# Altium schematic sheet Mcu.SchDoc
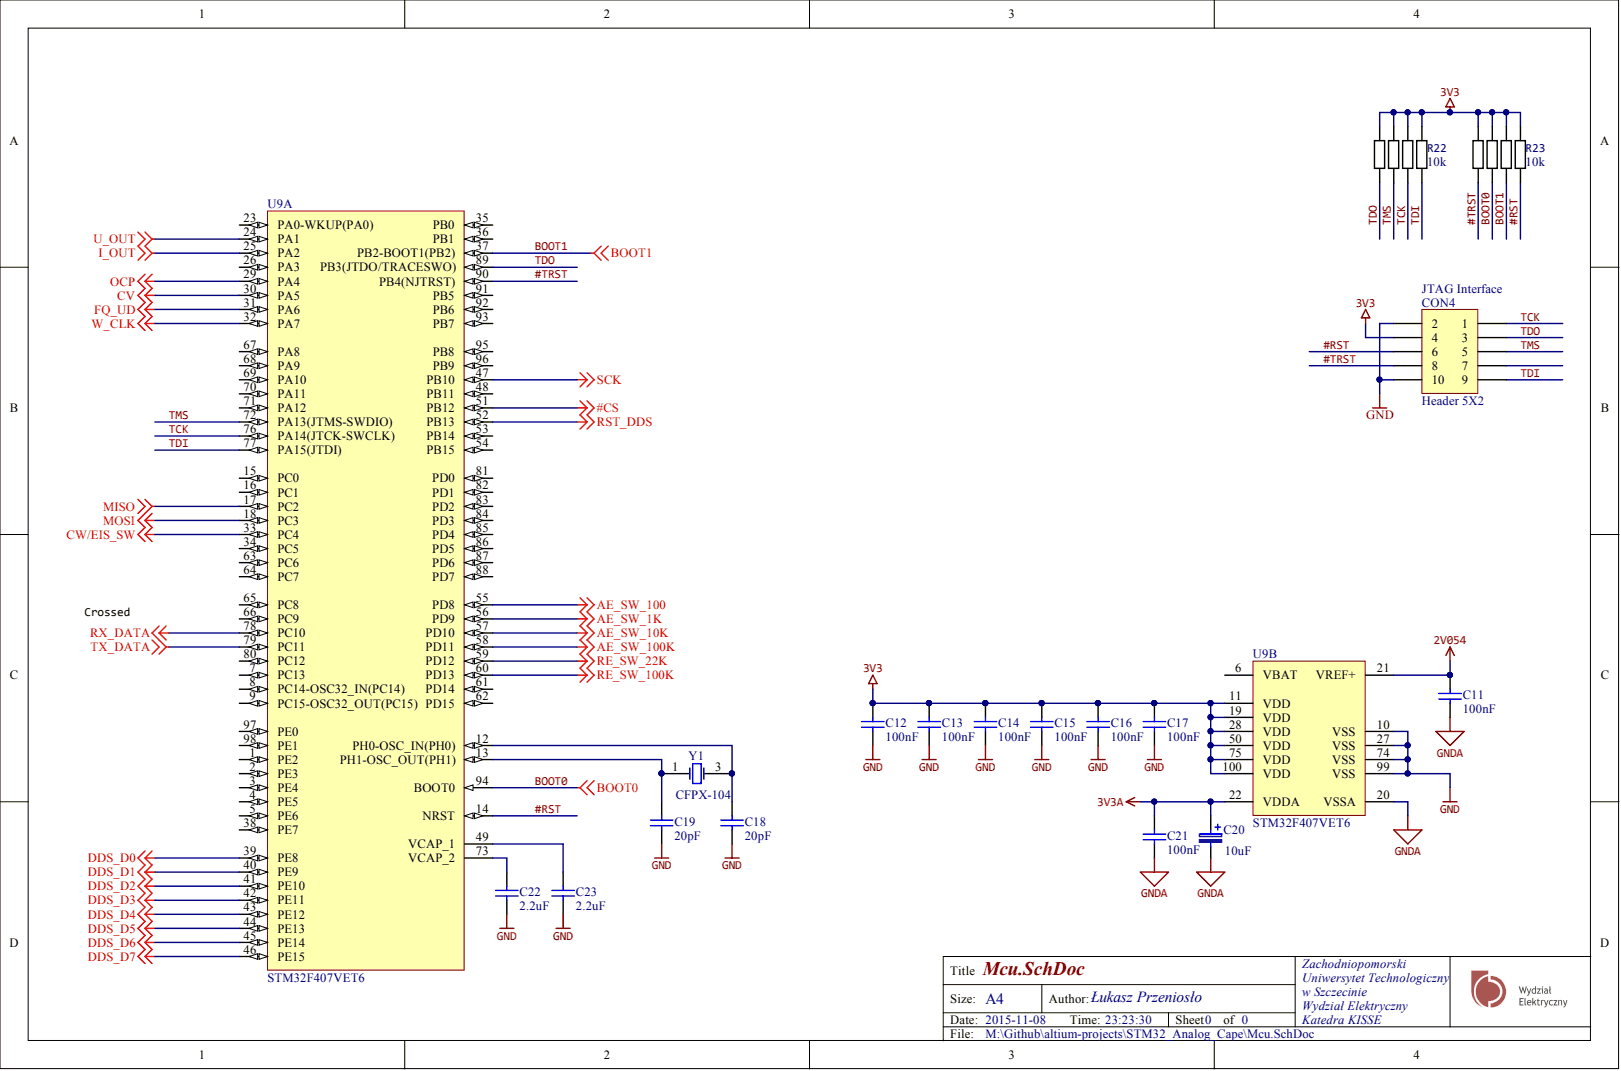
[diagram p0: Mcu.SchDoc]

## Parts
C11  100nF  [CAPC1608N]
C12  100nF  [CAPC1608N]
C13  100nF  [CAPC1608N]
C14  100nF  [CAPC1608N]
C15  100nF  [CAPC1608N]
C16  100nF  [CAPC1608N]
C17  100nF  [CAPC1608N]
C18  20pF  [CAPC1608N]
C19  20pF  [CAPC1608N]
C20  10uF  [SMDA]
C21  100nF  [CAPC1608N]
C22  2.2uF  [CAPC1608N]
C23  2.2uF  [CAPC1608N]
CON4  Header 5X2  [HDR1X10_1.27mmp_MIN]
R22  value 10k  [RES_ARRAY_4x0603]  (hidden comment: RES_ARRAY_4)
R23  value 10k  [RES_ARRAY_4x0603]  (hidden comment: RES_ARRAY_4)
U9  STM32F407VET6  [LQFP100_L]  (parts A,B)
Y1  CFPX-104  [CFPX-104]

## Nets
2V054: C11.2 U9.21(VREF+)
3V3: C12.2 C13.2 C14.2 C15.2 C16.2 C17.2 CON4.4 R22.2 R22.4 R22.6 R22.8 R23.2 R23.4 R23.6 R23.8 U9.11(VDD) U9.19(VDD) U9.28(VDD) U9.50(VDD) U9.75(VDD) U9.100(VDD)
3V3A: C20.1 C21.2 U9.22(VDDA)
#CS: U9.51(PB12)
AE_SW_1K: U9.56(PD9)
AE_SW_10K: U9.57(PD10)
AE_SW_100: U9.55(PD8)
AE_SW_100K: U9.58(PD11)
CV: U9.30(PA5)
CW/EIS_SW: U9.33(PC4)
DDS_D0: U9.39(PE8)
DDS_D1: U9.40(PE9)
DDS_D2: U9.41(PE10)
DDS_D3: U9.42(PE11)
DDS_D4: U9.43(PE12)
DDS_D5: U9.44(PE13)
DDS_D6: U9.45(PE14)
DDS_D7: U9.46(PE15)
FQ_UD: U9.31(PA6)
GND: C12.1 C13.1 C14.1 C15.1 C16.1 C17.1 C18.1 C19.1 C22.1 C23.1 CON4.2 CON4.10 U9.10(VSS) U9.27(VSS) U9.74(VSS) U9.99(VSS)
GNDA: C11.1 C20.2 C21.1 U9.20(VSSA)
I_OUT: U9.25(PA2)
MISO: U9.17(PC2)
MOSI: U9.18(PC3)
OCP: U9.29(PA4)
RE_SW_22K: U9.59(PD12)
RE_SW_100K: U9.60(PD13)
RST_DDS: U9.52(PB13)
RX_DATA: U9.78(PC10)
SCK: U9.47(PB10)
TX_DATA: U9.79(PC11)
U_OUT: U9.24(PA1)
W_CLK: U9.32(PA7)
#RST: CON4.6 R23.1 U9.14(NRST)
#TRST: CON4.8 R23.7 U9.90(PB4(NJTRST))
BOOT0: R23.5 U9.94(BOOT0)
BOOT1: R23.3 U9.37(PB2-BOOT1(PB2))
TCK: CON4.1 R22.3 U9.76(PA14(JTCK-SWCLK))
TDI: CON4.9 R22.1 U9.77(PA15(JTDI))
TDO: CON4.3 R22.7 U9.89(PB3(JTDO/TRACESWO))
TMS: CON4.5 R22.5 U9.72(PA13(JTMS-SWDIO))
NetC18_2: C18.2 U9.12(PH0-OSC_IN(PH0)) Y1.3
NetC19_2: C19.2 U9.13(PH1-OSC_OUT(PH1)) Y1.1
NetC22_2: C22.2 U9.73(VCAP_2)
NetC23_2: C23.2 U9.49(VCAP_1)

Not connected: CON4 7; U9 1(PE2) 2(PE3) 3(PE4) 4(PE5) 5(PE6) 6(VBAT) 7(PC13) 8(PC14-OSC32_IN(PC14)) 9(PC15-OSC32_OUT(PC15)) 15(PC0) 16(PC1) 23(PA0-WKUP(PA0)) 26(PA3) 34(PC5) 35(PB0) 36(PB1) 38(PE7) 48(PB11) 53(PB14) 54(PB15) 61(PD14) 62(PD15) 63(PC6) 64(PC7) 65(PC8) 66(PC9) 67(PA8) 68(PA9) 69(PA10) 70(PA11) 71(PA12) 80(PC12) 81(PD0) 82(PD1) 83(PD2) 84(PD3) 85(PD4) 86(PD5) 87(PD6) 88(PD7) 91(PB5) 92(PB6) 93(PB7) 95(PB8) 96(PB9) 97(PE0) 98(PE1)

## Library data of the parts
C11-C19 C21-C23 = Capacitor: Component Kind: Standard; Description: Capacitor; Library Name: Discrete.SchLib; Signal Integrity: Cap; Simulation: CAP
  C11-C17 C21: Value: 100nF
  C18 C19: Value: 20pF
  C22 C23: Value: 2.2uF
C20 = 100uF 6.3V-SMDB: Polarized Capacitor (Surface Mount); Component Kind: Standard; Distributor: tme.pl; Distributor Order Code: TC 100/6.3-SMDB; farnell: 1135048; PackageReference: SMDB; Signal Integrity: Cap Pol; Simulation: CAP; tme.pl: TC 100/6.3-SMDB; Value: 10uF; Library Name: Mechatronic.SchLib
CON4 = Header 5X2: Header, 5-Pin, Dual row; Component Kind: Standard; LatestRevisionDate: 17-Jul-2002; LatestRevisionNote: Re-released for DXP Platform.; Library Name: Miscellaneous Connectors.IntLib; Signal Integrity: Connector; Publisher: Altium Limited
R22 R23 = RES_ARRAY_1206: Component Kind: Standard; Library Name: my_various_devices.SchLib
U9 = STM32F407VET6: ARM Cortex-M4 32-bit MCU+FPU, 210 DMIPS, 512 kB Flash, 192 kB Internal RAM, 82 I/Os, 100-pin LQFP, -40 to 85 degC, Tray; Manufacturer: STMicroelectronics; D/A Converter: 2x12-bit; FLASH Size (Prog) (kB): 512; I/Os (High Current): 82; Internal RAM Size (kB): 192; Operating Frequency(F) (Processor speed) (MHz): 168; Supply Voltage(Vcc) max (V): 3.6; Supply Voltage(Vcc) min (V): 1.8; Component Kind: Standard; ComponentLink1Description: Manufacturer URL; ComponentLink1URL: http://www.st.com/web/en/home.html; ComponentLink2Description: Datasheet; ComponentLink2URL: http://www.st.com/st-web-ui/static/active/en/resource/technical/document/datasheet/DM00037051.pdf; ComponentLink3Description: Package Specification; ComponentLink3URL: http://www.st.com/st-web-ui/static/active/en/resource/technical/document/data_brief/DM00071938.pdf; Core: ARM Cortex-M4; DatasheetVersion: Rev. 4; Mounting Technology: Surface Mount; PackageDescription: 100-Pin Low Profile Quad Flat Package, 14 x 14 mm Body, 0.5 mm Pitch; PackageReference: LQFP100; PackageVersion: Rev. 1, 06/2013; Packing: Tray; PartId: 1; PartNumber: STM32F407VET6; Library Name: my_various_devices.SchLib
Y1 = CFPX-104: Component Kind: Standard; Description: CFPX-104; Library Name: my_various_devices.SchLib
